# Altium schematic sheet Esp32_Button.SchDoc
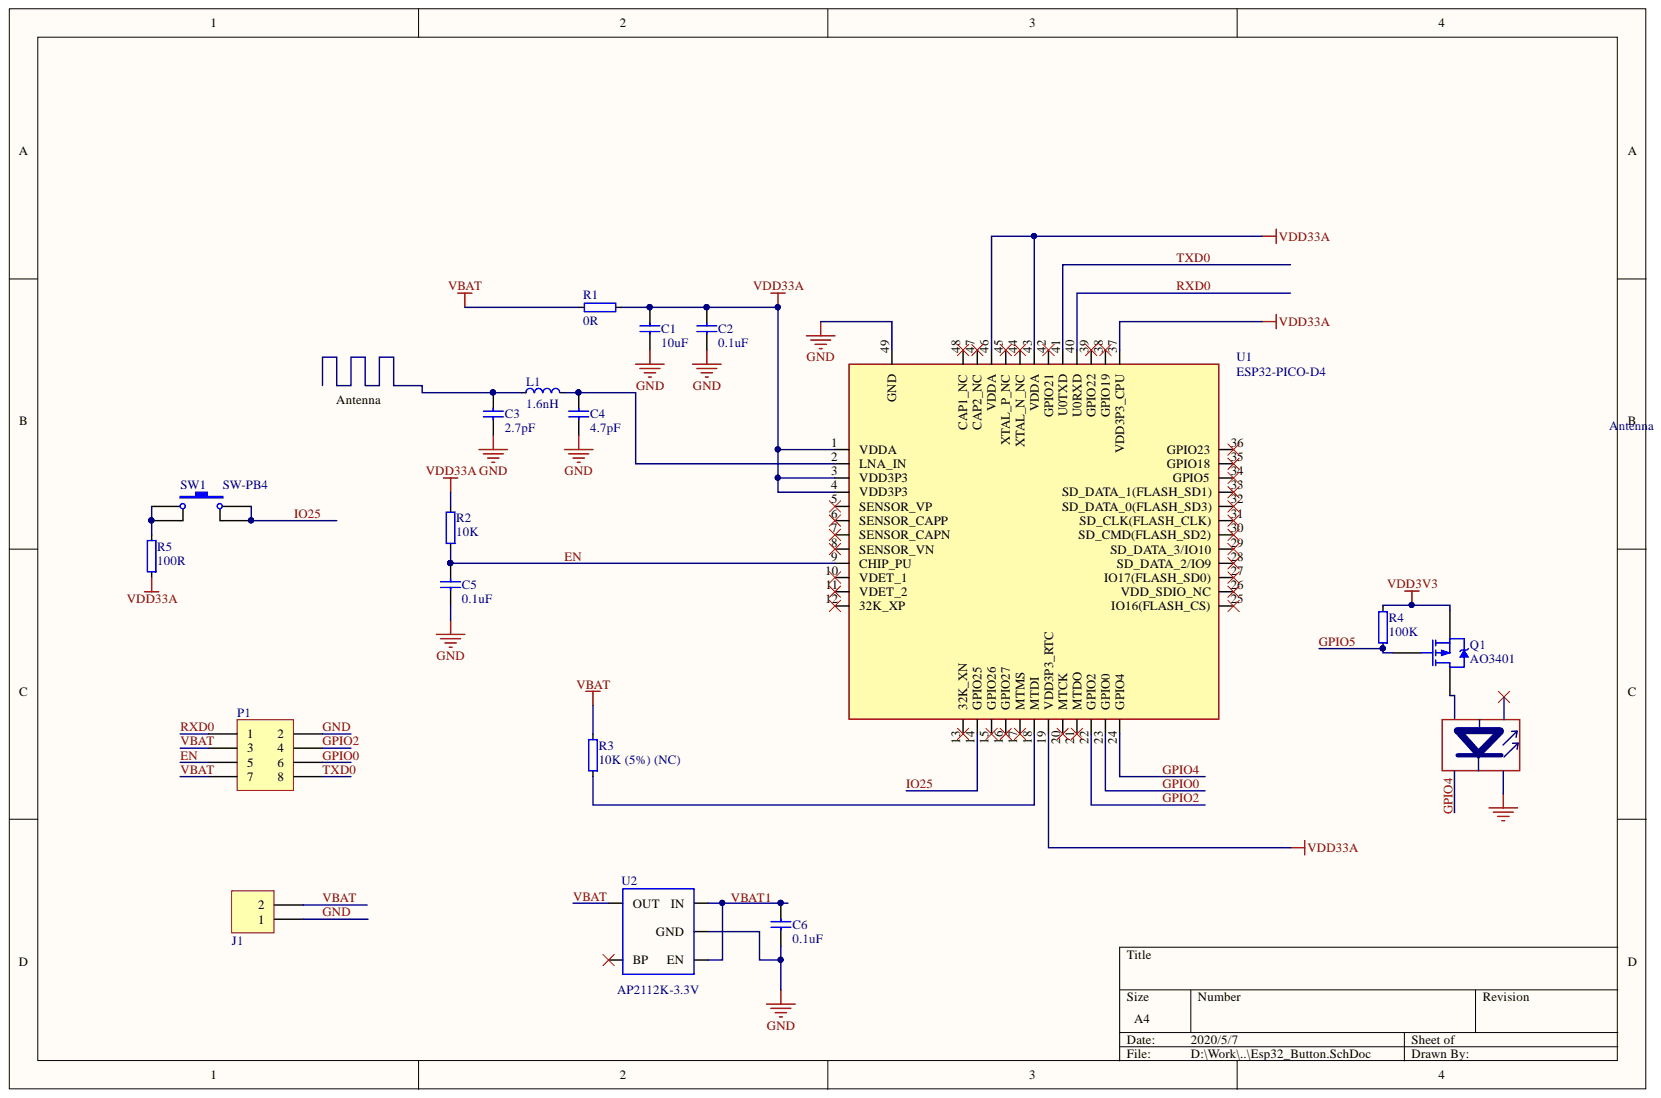
[diagram p0: Esp32_Button.SchDoc]

## Parts
C1  10uF  [CAPC1005X04L]
C2  0.1uF  [CAPC1005X04L]
C3  2.7pF  [CAPC1005X04L]
C4  4.7pF  [CAPC1005X04L]
C5  0.1uF  [CAPC1005X04L]
C6  0.1uF  [CAPC1005X04L]
J1  Header 2  [HDR2X1_A]  (value not drawn)
L1  1.6nH  [INDC1005X04L]
P1  Header 4X2  [HDR2X4]  (value not drawn)
Q1  AO3401  [SOT23]
R1  0R  [RESC1005X04L]
R2  10K  [RESC1005X04L]
R3  10K (5%) (NC)  Value Ohm: 10K  [RESC1005X04L]
R4  100K  [RESC1005X04L]
R5  100R  [RESC1005X04L]
SW1  SW-PB4  [SW_PB4_A1]
U1  ESP32-PICO-D4  [QFN48_7*7]
U2  AP2112K-3.3V  [SOT23-5]

## Nets
VDD3V3: Q1.3 R4.1
VDD33A: C1.2 C2.2 R1.1 R2.1 R5.2 U1.1(VDDA) U1.3(VDD3P3) U1.4(VDD3P3) U1.19(VDD3P3_RTC) U1.37(VDD3P3_CPU) U1.43(VDDA) U1.46(VDDA)
EN: C5.2 P1.5 R2.2 U1.9(CHIP_PU)
GND: C1.1 C2.1 C3.1 C4.1 C5.1 C6.1 P1.2 U1.49(GND) U2.2(GND)
GPIO0: P1.6 U1.23(GPIO0)
GPIO2: P1.4 U1.22(GPIO2)
GPIO4: U1.24(GPIO4)
GPIO5: Q1.1 R4.2
IO25: SW1.2 SW1.3 U1.14(GPIO25)
RXD0: P1.1 U1.40(U0RXD)
TXD0: P1.8 U1.41(U0TXD)
VBAT: P1.3 P1.7 R1.2 R3.1 U2.5(OUT)
NetC3_2: C3.2 L1.1
NetC4_2: C4.2 L1.2 U1.2(LNA_IN)
NetC6_2: C6.2 U2.1(IN) U2.3(EN)
NetR3_2: R3.2 U1.18(MTDI)
NetR5_1: R5.1 SW1.1 SW1.4
N00008:
N00009:
N00010:
N00011:
N00012:
N00013:
N00014:
N00015:
N00016:
N00017:
N00018:
N00019:
N00020:
N00021:
VBAT1:

Not connected: J1 1 2; Q1 2; U1 5(SENSOR_VP) 6(SENSOR_CAPP) 7(SENSOR_CAPN) 8(SENSOR_VN) 10(VDET_1) 11(VDET_2) 12(32K_XP) 13(32K_XN) 15(GPIO26) 16(GPIO27) 17(MTMS) 20(MTCK) 21(MTDO) 25(IO16(FLASH_CS)) 26(VDD_SDIO_NC) 27(IO17(FLASH_SD0)) 28(SD_DATA_2/IO9) 29(SD_DATA_3/IO10) 30(SD_CMD(FLASH_SD2)) 31(SD_CLK(FLASH_CLK)) 32(SD_DATA_0(FLASH_SD3)) 33(SD_DATA_1(FLASH_SD1)) 34(GPIO5) 35(GPIO18) 36(GPIO23) 38(GPIO19) 39(GPIO22) 42(GPIO21) 44(XTAL_N_NC) 45(XTAL_P_NC) 47(CAP2_NC) 48(CAP1_NC); U2 4(BP)

## Library data of the parts
C1 = C0201-1.0p: 10UF/6.3V (10%); Part number IPS: 00000001247; Artikkelnr Noca: N.A.; Database Table Name: Capacitors$; Note: 50V; Artikkelnr Simpro: N.A.; Component Kind: Standard; ComponentLink1Description: Datasheet; ComponentLink2Description: Supplier link; Footprint Doc: 0201; LatestRevisionDate: 2017-11-09; Library Name: Nordic Passive DB.DbLib; Part Number: C0201-1.0p; Pin Count: 2; Published: 2014-11-13; Value: 10uF; Publisher: Nordic Semiconductor
C2 C5 C6 = C0201-1.0p: 0.1uF/25V(10%); Part number IPS: 00000001247; Artikkelnr Noca: N.A.; Database Table Name: Capacitors$; Note: 50V; Artikkelnr Simpro: N.A.; Component Kind: Standard; ComponentLink1Description: Datasheet; ComponentLink2Description: Supplier link; Footprint Doc: 0201; LatestRevisionDate: 2017-11-09; Library Name: Nordic Passive DB.DbLib; Part Number: C0201-1.0p; Pin Count: 2; Published: 2014-11-13; Value: 0.1uF; Publisher: Nordic Semiconductor
C3 = C0201-1.0p: 2.7pF/25V(±0.5pF); Part number IPS: 00000001247; Artikkelnr Noca: N.A.; Database Table Name: Capacitors$; Note: 50V; Artikkelnr Simpro: N.A.; Component Kind: Standard; ComponentLink1Description: Datasheet; ComponentLink2Description: Supplier link; Footprint Doc: 0201; LatestRevisionDate: 2017-11-09; Library Name: Nordic Passive DB.DbLib; Part Number: C0201-1.0p; Pin Count: 2; Published: 2014-11-13; Value: 2.7pF; Publisher: Nordic Semiconductor
C4 = C0201-1.0p: 4.7pF/25V(±0.5pF); Part number IPS: 00000001247; Artikkelnr Noca: N.A.; Database Table Name: Capacitors$; Note: 50V; Artikkelnr Simpro: N.A.; Component Kind: Standard; ComponentLink1Description: Datasheet; ComponentLink2Description: Supplier link; Footprint Doc: 0201; LatestRevisionDate: 2017-11-09; Library Name: Nordic Passive DB.DbLib; Part Number: C0201-1.0p; Pin Count: 2; Published: 2014-11-13; Value: 4.7pF; Publisher: Nordic Semiconductor
J1 = Header 2: 接插件; Component Kind: Standard; LatestRevisionDate: 17-Jul-2002; LatestRevisionNote: Re-released for DXP Platform.; Library Name: ablib.SchLib; Publisher: Altium Limited
L1 = L0201-3n9: 1.6nH±0.3nH; Part number IPS: 00000001253; Artikkelnr Noca: N.A.; Database Table Name: Inductors$; Note: High frequency chip inductor ±5%; Artikkelnr Simpro: N.A.; Component Kind: Standard; ComponentLink1Description: Datasheet; ComponentLink2Description: Supplier link; Footprint Doc: 0201; LatestRevisionDate: 2017-11-09; Library Name: Nordic Passive DB.DbLib; Part Number: L0201-3n9; Pin Count: 2; Published: 2013-04-10; Value: 1.6nH; Publisher: Nordic Semiconductor
P1 = Header 4X2: Header, 4-Pin, Dual row; Component Kind: Standard; LatestRevisionDate: 17-Jul-2002; LatestRevisionNote: Re-released for DXP Platform.; Library Name: Miscellaneous Connectors.IntLib; Signal Integrity: Connector; Publisher: Altium Limited
Q1 = PMOS-2: P-Channel Power MOSFET; Note: Pin 4 not drawn and electrically connected to Pin 2.; Code_JEDEC: TO-220-AB; Component Kind: Standard; ComponentLink1Description: Manufacturer Link; ComponentLink1URL: http://www.irf.com/; DatasheetVersion: PD-9.390D; LatestRevisionDate: 17-Jul-2002; LatestRevisionNote: Re-released for DXP Platform.; Library Name: Miscellaneous Devices.IntLib; PackageReference: TO-220AB; Published: 8-Jun-2000; Simulation: IRF9510; Publisher: Altium Limited
R1 = R0201-0R: 0R (1%); Part number IPS: 00000003245; Artikkelnr Noca: N.A.; Database Table Name: Resistors$; Note: Chip Res 5%; Value Ohm: 0; Artikkelnr Simpro: N.A.; Component Kind: Standard; ComponentLink1Description: Datasheet; ComponentLink2Description: Supplier link; Footprint Doc: 0201; LatestRevisionDate: 2017-11-09; Library Name: Nordic Passive DB.DbLib; Part Number: R0201-0R; Pin Count: 2; Published: 2014-11-13; Value: 0R; Publisher: Nordic Semiconductor
R2-R5 = R0201-0R: 10K (1%); Part number IPS: 00000003245; Artikkelnr Noca: N.A.; Database Table Name: Resistors$; Note: Chip Res 5%; Artikkelnr Simpro: N.A.; Component Kind: Standard; ComponentLink1Description: Datasheet; ComponentLink2Description: Supplier link; Footprint Doc: 0201; LatestRevisionDate: 2017-11-09; Library Name: Nordic Passive DB.DbLib; Part Number: R0201-0R; Pin Count: 2; Published: 2014-11-13; Publisher: Nordic Semiconductor
  R2: Value: 10K; Value Ohm: 10K
  R3: Value: 10K (5%) (NC)
  R4: Value: 100K; Value Ohm: 10K
  R5: Value: 100R; Value Ohm: 10K
SW1 = SW-PB4: 微动开关; Component Kind: Standard; LatestRevisionDate: 17-Jul-2002; LatestRevisionNote: Re-released for DXP Platform.; PackageReference: SPST-2; Published: 24-Mar-1999; Library Name: ablib.SchLib; Publisher: Altium Limited
U2 = V_REG_LDOSMD: Component Kind: Standard; Library Name: SparkFun
U1 = ESP32-PICO-D4: Component Kind: Standard; Library Name: ablib.SchLib
